# Altium schematic sheet main.SchDoc
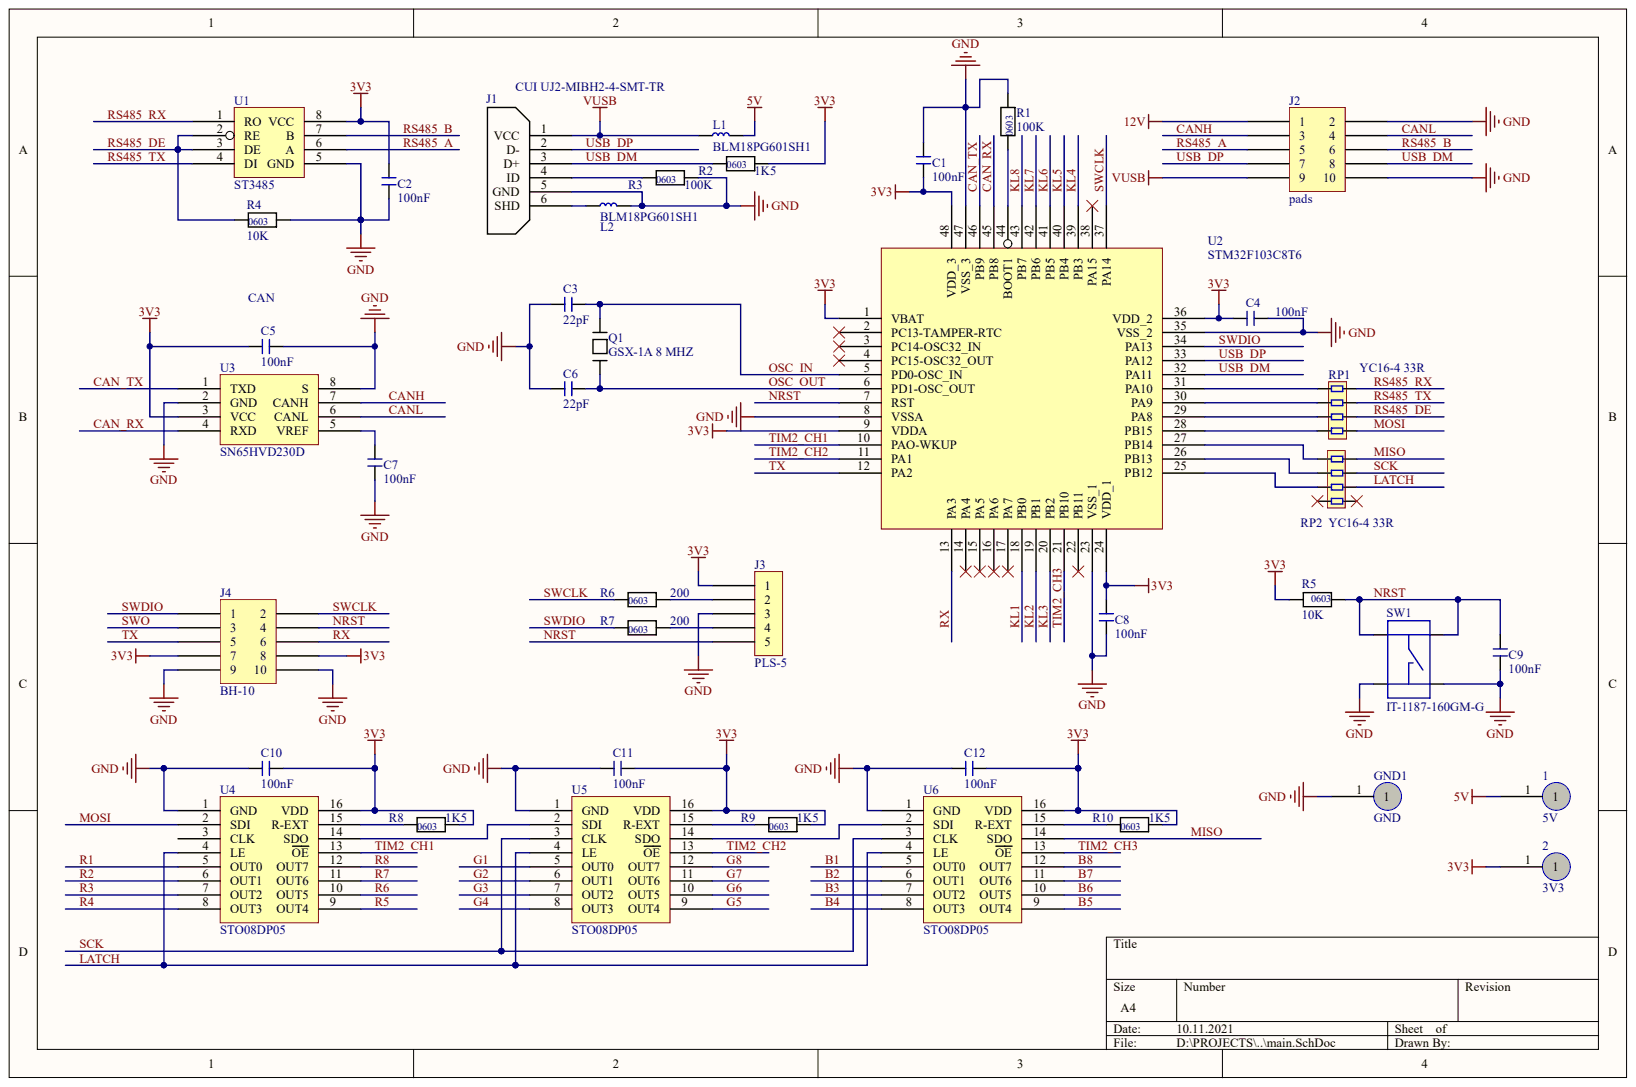
[diagram p0: main.SchDoc]

## Parts
1  5V
2  3V3
C1  100nF
C2  100nF
C3  22pF
C4  100nF
C5  100nF
C6  22pF
C7  100nF
C8  100nF
C9  100nF
C10  100nF
C11  100nF
C12  100nF
GND1  GND
J1  CUI UJ2-MIBH2-4-SMT-TR
J2  pads
J3  PLS-5
J4  BH-10
L1  BLM18PG601SH1
L2  BLM18PG601SH1
Q1  GSX-1A 8 MHZ
R1  100K
R2  1K5
R3  100K
R4  10K
R5  10K
R6  200
R7  200
R8  1K5
R9  1K5
R10  1K5
RP1  YC16-4 33R
RP2  YC16-4 33R
SW1  IT-1187-160GM-G
U1  ST3485
U2  STM32F103C8T6
U3  SN65HVD230D
U4  STO08DP05
U5  STO08DP05
U6  STO08DP05

## Nets
3V3: 2.1 C1.2 C2.2 C4.2 C5.2 C8.2 C10.2 C11.2 C12.2 J3.1 J4.7 J4.8 R2.2 R5.2 R8.2 R9.2 R10.2 U1.8(VCC) U2.1(VBAT) U2.9(VDDA) U2.24(VDD_1) U2.36(VDD_2) U2.48(VDD_3) U3.3(VCC) U4.16(VDD) U5.16(VDD) U6.16(VDD)
5V: 1.1 L1.2
12V: J2.1
GND: C1.1 C2.1 C3.1 C4.1 C5.1 C6.1 C7.1 C8.1 C9.1 C10.1 C11.1 C12.1 GND1.1 J1.5(GND) J2.2 J2.10 J3.3 J4.9 J4.10 L2.2 R1.2 R3.2 R4.2 SW1.3 SW1.4 U1.5(GND) U2.8(VSSA) U2.23(VSS_1) U2.35(VSS_2) U2.47(VSS_3) U3.2(GND) U3.8(S) U4.1(GND) U5.1(GND) U6.1(GND)
VUSB: J1.1(VCC) J2.9 L1.1
B1: U6.5(OUT0)
B2: U6.6(OUT1)
B3: U6.7(OUT2)
B4: U6.8(OUT3)
B5: U6.9(OUT4)
B6: U6.10(OUT5)
B7: U6.11(OUT6)
B8: U6.12(OUT7)
CAN_RX: U2.45(PB8) U3.4(RXD)
CAN_TX: U2.46(PB9) U3.1(TXD)
CANH: J2.3 U3.7(CANH)
CANL: J2.4 U3.6(CANL)
G1: U5.5(OUT0)
G2: U5.6(OUT1)
G3: U5.7(OUT2)
G4: U5.8(OUT3)
G5: U5.9(OUT4)
G6: U5.10(OUT5)
G7: U5.11(OUT6)
G8: U5.12(OUT7)
KL1: U2.18(PB0)
KL2: U2.19(PB1)
KL3: U2.20(PB2)
KL4: U2.39(PB3)
KL5: U2.40(PB4)
KL6: U2.41(PB5)
KL7: U2.42(PB6)
KL8: U2.43(PB7)
LATCH: RP2.2 U4.4(LE) U5.4(LE) U6.4(LE)
MISO: RP2.4 U6.14(SDO)
MOSI: RP1.5 U4.2(SDI)
NRST: C9.2 J3.5 J4.4 R5.1 SW1.1 SW1.2 U2.7(RST)
OSC_IN: C3.2 Q1.2 U2.5(PD0-OSC_IN)
OSC_OUT: C6.2 Q1.1 U2.6(PD1-OSC_OUT)
R1: U4.5(OUT0)
R2: U4.6(OUT1)
R3: U4.7(OUT2)
R4: U4.8(OUT3)
R5: U4.9(OUT4)
R6: U4.10(OUT5)
R7: U4.11(OUT6)
R8: U4.12(OUT7)
RS485_A: J2.5 U1.6(A)
RS485_B: J2.6 U1.7(B)
RS485_DE: R4.1 RP1.6 U1.2(RE) U1.3(DE)
RS485_RX: RP1.8 U1.1(RO)
RS485_TX: RP1.7 U1.4(DI)
RX: J4.6 U2.13(PA3)
SCK: RP2.3 U5.3(CLK) U6.3(CLK)
SWCLK: J4.2 R6.1 U2.37(PA14)
SWDIO: J4.1 R7.1 U2.34(PA13)
SWO: J4.3
TIM2_CH1: U2.10(PAO-WKUP) U4.13(OE)
TIM2_CH2: U2.11(PA1) U5.13(OE)
TIM2_CH3: U2.21(PB10) U6.13(OE)
TX: J4.5 U2.12(PA2)
USB_DM: J1.3(D+) J2.8 R2.1 U2.32(PA11)
USB_DP: J1.2(D-) J2.7 U2.33(PA12)
NetC7_2: C7.2 U3.5(VREF)
NetJ1_4: J1.4(ID) R3.1
NetJ1_6: J1.6(SHD) L2.1
NetJ3_2: J3.2 R6.2
NetJ3_4: J3.4 R7.2
NetR1_1: R1.1 U2.44(BOOT1)
NetR8_1: R8.1 U4.15(R-EXT)
NetR9_1: R9.1 U5.15(R-EXT)
NetR10_1: R10.1 U6.15(R-EXT)
NetRP1_1: RP1.1 U2.31(PA10)
NetRP1_2: RP1.2 U2.30(PA9)
NetRP1_3: RP1.3 U2.29(PA8)
NetRP1_4: RP1.4 U2.28(PB15)
NetRP2_5: RP2.5 U2.27(PB14)
NetRP2_6: RP2.6 U2.26(PB13)
NetRP2_7: RP2.7 U2.25(PB12)
NetU4_14: U4.14(SDO) U5.2(SDI)
NetU5_14: U5.14(SDO) U6.2(SDI)

Not connected: RP2 1 8; U2 2(PC13-TAMPER-RTC) 3(PC14-OSC32_IN) 4(PC15-OSC32_OUT) 14(PA4) 15(PA5) 16(PA6) 17(PA7) 22(PB11) 38(PA15); U4 3(CLK)
